# Altium schematic sheet FTDI.SchDoc
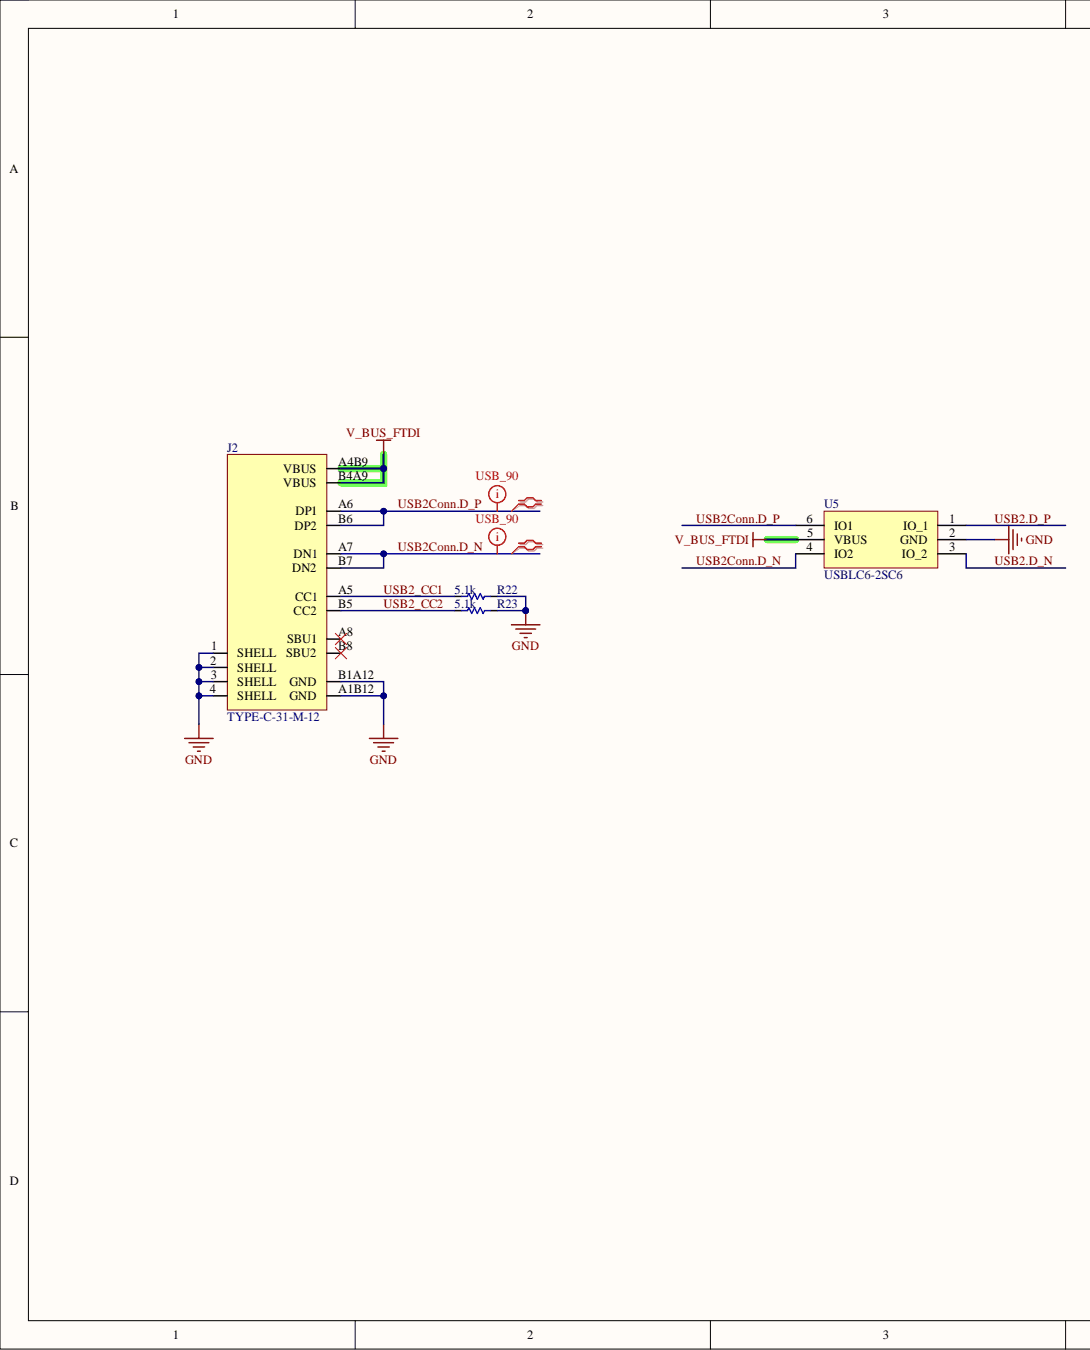
[diagram p0: FTDI.SchDoc, left]
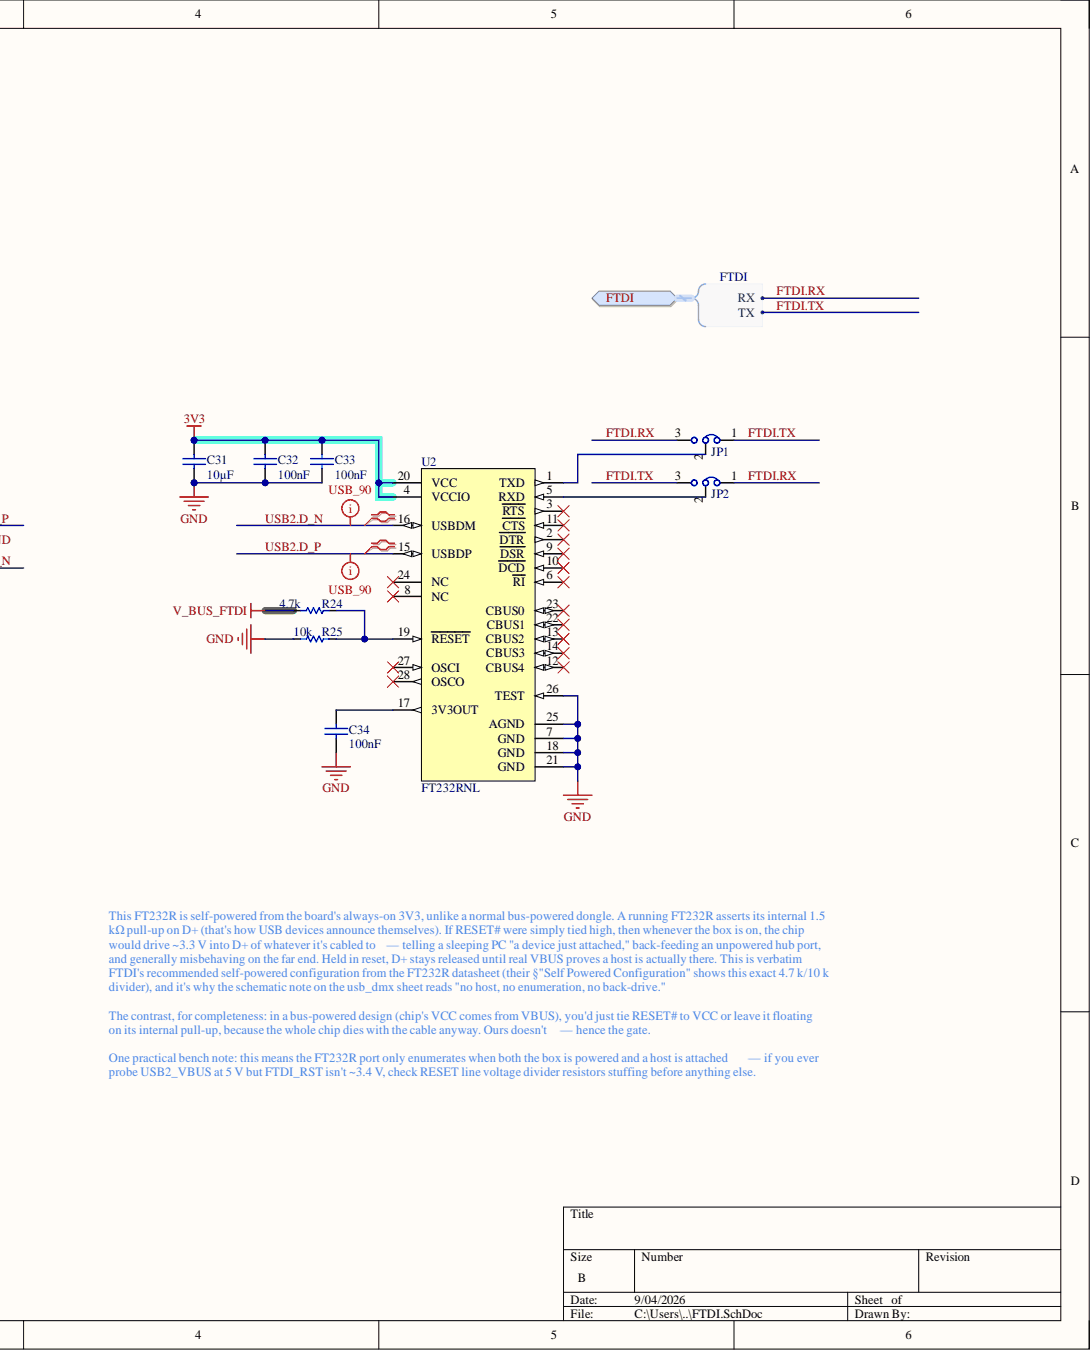
[diagram p1: FTDI.SchDoc, right]

## Parts
C31  value 10µF  [CAPC2012X14N_0805_MED]  (hidden comment: GRM21BC81C106KA73L)
C32  value 100nF  [CAPC1608X10N_0603_MED]  (hidden comment: CC0603KRX7R0BB104)
C33  value 100nF  [CAPC1608X10N_0603_MED]  (hidden comment: CC0603KRX7R0BB104)
C34  value 100nF  [CAPC1608X10N_0603_MED]  (hidden comment: CC0603KRX7R0BB104)
J2  TYPE-C-31-M-12  [Type-C-31-M-12]
JP1  Jumper 3 x 1 Bridge 1-2 Solder Bridge  [Jumper 3 Solder Bridge 1-2 0603]  (value not drawn)
JP2  Jumper 3 x 1 Bridge 1-2 Solder Bridge  [Jumper 3 Solder Bridge 1-2 0603]  (value not drawn)
R22  value 5.1k  [RESC1608X06N_0603_MED]  (hidden comment: RC0603FR-075K1L)
R23  value 5.1k  [RESC1608X06N_0603_MED]  (hidden comment: RC0603FR-075K1L)
R24  value 4.7k  [RESC1608X06N_0603_MED]  (hidden comment: RC0603FR-074K7L)
R25  value 10k  [RESC1608X06N_0603_MED]  (hidden comment: RC0603FR-1010KL)
U2  FT232RNL  [FP-FT232RNL-REEL-MFG]
U5  USBLC6-2SC6  [ESD_SOT23-6L]

## Ports
FTDI, FTDI.RX, FTDI.TX

## Nets
3V3: C31.2 C32.1 C33.1 U2.4(VCCIO) U2.20(VCC)
GND: C31.1 C32.2 C33.2 C34.2 J2.1(SHELL) J2.2(SHELL) J2.3(SHELL) J2.4(SHELL) J2.A1B12(GND) J2.B1A12(GND) R22.2 R23.2 R25.1 U2.7(GND) U2.18(GND) U2.21(GND) U2.25(AGND) U2.26(TEST) U5.2(GND)
V_BUS_FTDI: J2.A4B9(VBUS) J2.B4A9(VBUS) R24.2 U5.5(VBUS)
FTDI.RX: JP1.3 JP2.1 ; port FTDI
FTDI.TX: JP1.1 JP2.3 ; port FTDI
USB2.D_N: U2.16(USBDM) U5.3(IO_2)
USB2.D_P: U2.15(USBDP) U5.1(IO_1)
USB2_CC1: J2.A5(CC1) R22.1
USB2_CC2: J2.B5(CC2) R23.1
USB2Conn.D_N: J2.A7(DN1) J2.B7(DN2) U5.4(IO2)
USB2Conn.D_P: J2.A6(DP1) J2.B6(DP2) U5.6(IO1)
NetC34_1: C34.1 U2.17(3V3OUT)
NetJ2_A8: J2.A8(SBU1)
NetJ2_B8: J2.B8(SBU2)
NetJP1_2: JP1.2 U2.1(TXD)
NetJP2_2: JP2.2 U2.5(RXD)
NetR24_1: R24.1 R25.2 U2.19(RESET)
NetU2_2: U2.2(DTR)
NetU2_3: U2.3(RTS)
NetU2_6: U2.6(RI)
NetU2_8: U2.8(NC)
NetU2_9: U2.9(DSR)
NetU2_10: U2.10(DCD)
NetU2_11: U2.11(CTS)
NetU2_12: U2.12(CBUS4)
NetU2_13: U2.13(CBUS2)
NetU2_14: U2.14(CBUS3)
NetU2_22: U2.22(CBUS1)
NetU2_23: U2.23(CBUS0)
NetU2_24: U2.24(NC)
NetU2_27: U2.27(OSCI)
NetU2_28: U2.28(OSCO)

## Library data of the parts
C31 = CMP-001-00084-4: Ceramic Capacitor, Multilayer, Ceramic, 10uF, 16V, 10%, X6S, 0805; Capacitor Type: Ceramic; Case/Package: 0805; Component Kind: Standard; JLCPN: C162413; Max Operating Temperature: 105°C; Min Operating Temperature: -55°C; Mounting Technology: Surface Mount; Pins: 2; RoHS Compliant: Yes; Tolerance: 10%; Voltage Rating: 16V; Library Name: Equus Computer Systems
C32-C34 = CMP-001-00102-4: Ceramic Capacitor, Multilayer, Ceramic, 100V, 10% +Tol, 10% -Tol, X7R, 15% TC, 0.1uF, Surface Mount, 0603; Capacitor Type: Ceramic; Case/Package: 0603; Component Kind: Standard; JLCPN: C113803; Max Operating Temperature: 125°C; Min Operating Temperature: -55°C; Mounting Technology: Surface Mount; Pins: 2; RoHS Compliant: Yes; Voltage Rating: 100V; Library Name: Equus Computer Systems
J2 = CMP-002-00236-8: 20V USB C 2.0 16P Female header; Component Kind: Standard; JLCPN: C165948; Library Name: Equus Computer Systems
JP1 JP2 = CMP-009-00341-2: 0603 Solder Bridge Jumper Surface Mount; Tolerance: 1%; Value: 0; Voltage Rating: 75V; Case/Package: 0603; Component Kind: Standard; Max Operating Temperature: 155°C; Min Operating Temperature: -55°C; Mounting Technology: Surface Mount; Pins: 2; RoHS Compliant: Yes; Library Name: Equus Computer Systems
R22 R23 = CMP-009-00331-3: Fixed Resistor, Metal Glaze/thick Film, 0.1W, 5100ohm, 75V, 1% +/-Tol, 100ppm/Cel, Surface Mount, 0603; Power: 100mW; Case/Package: 0603; Component Kind: Standard; JLCPN: C23186; Max Operating Temperature: 155°C; Min Operating Temperature: -55°C; Mounting Technology: Surface Mount; Pins: 2; RoHS Compliant: Yes; Tolerance: 1%; Voltage Rating: 75V; Library Name: Equus Computer Systems
R24 = CMP-009-00343-1: Fixed Resistor, Metal Glaze/thick Film, 0.1W, 4700ohm, 75V, 1% +/-Tol, 100ppm/Cel, Surface Mount, 0603; Power: 100mW; Case/Package: 0603; Component Kind: Standard; Max Operating Temperature: 155°C; Min Operating Temperature: -55°C; Mounting Technology: Surface Mount; Pins: 2; RoHS Compliant: Yes; Tolerance: 1%; Voltage Rating: 75V; Library Name: Equus Computer Systems
R25 = CMP-009-00306-2: Fixed Resistor, Metal Glaze/thick Film, 0.1W, 10000ohm, 75V, 1% +/-Tol, 100ppm/Cel, Surface Mount, 0603; Power: 100mW; Voltage Rating: 50V; Case/Package: 0603; Component Kind: Standard; JLCPN: C98220; Max Operating Temperature: 155°C; Min Operating Temperature: -55°C; Mounting Technology: Surface Mount; Pins: 2; RoHS Compliant: Yes; Tolerance: 1%; Library Name: Equus Computer Systems
U2 = CMP-015-00034-4: Integrated Circuit Interface USB-UART; RS-232; 12Mbs; 3.3-5.25V; -40+85 deg.C; SMD; SSOP28; Max Supply Voltage: 5.25V; Min Supply Voltage: 3.3V; Case/Package: SSOP; Component Kind: Standard; Max Operating Temperature: 85°C; Min Operating Temperature: -40°C; Mounting Technology: Surface Mount; Pins: 28; Library Name: Equus Computer Systems
U5 = CMP-128-000006-4: Very low capacitance ESD protection; Manufacturer: STMICROELECTRONICS; Part_Type: IC; Component Kind: Standard; JLCPN: C7519; Manufacturer_Part_Number: USBLC6-2SC6; Value: USBLC6-2SC6; Library Name: Equus Computer Systems
